# Altium schematic sheet New Javanes Sheet2.SchDoc
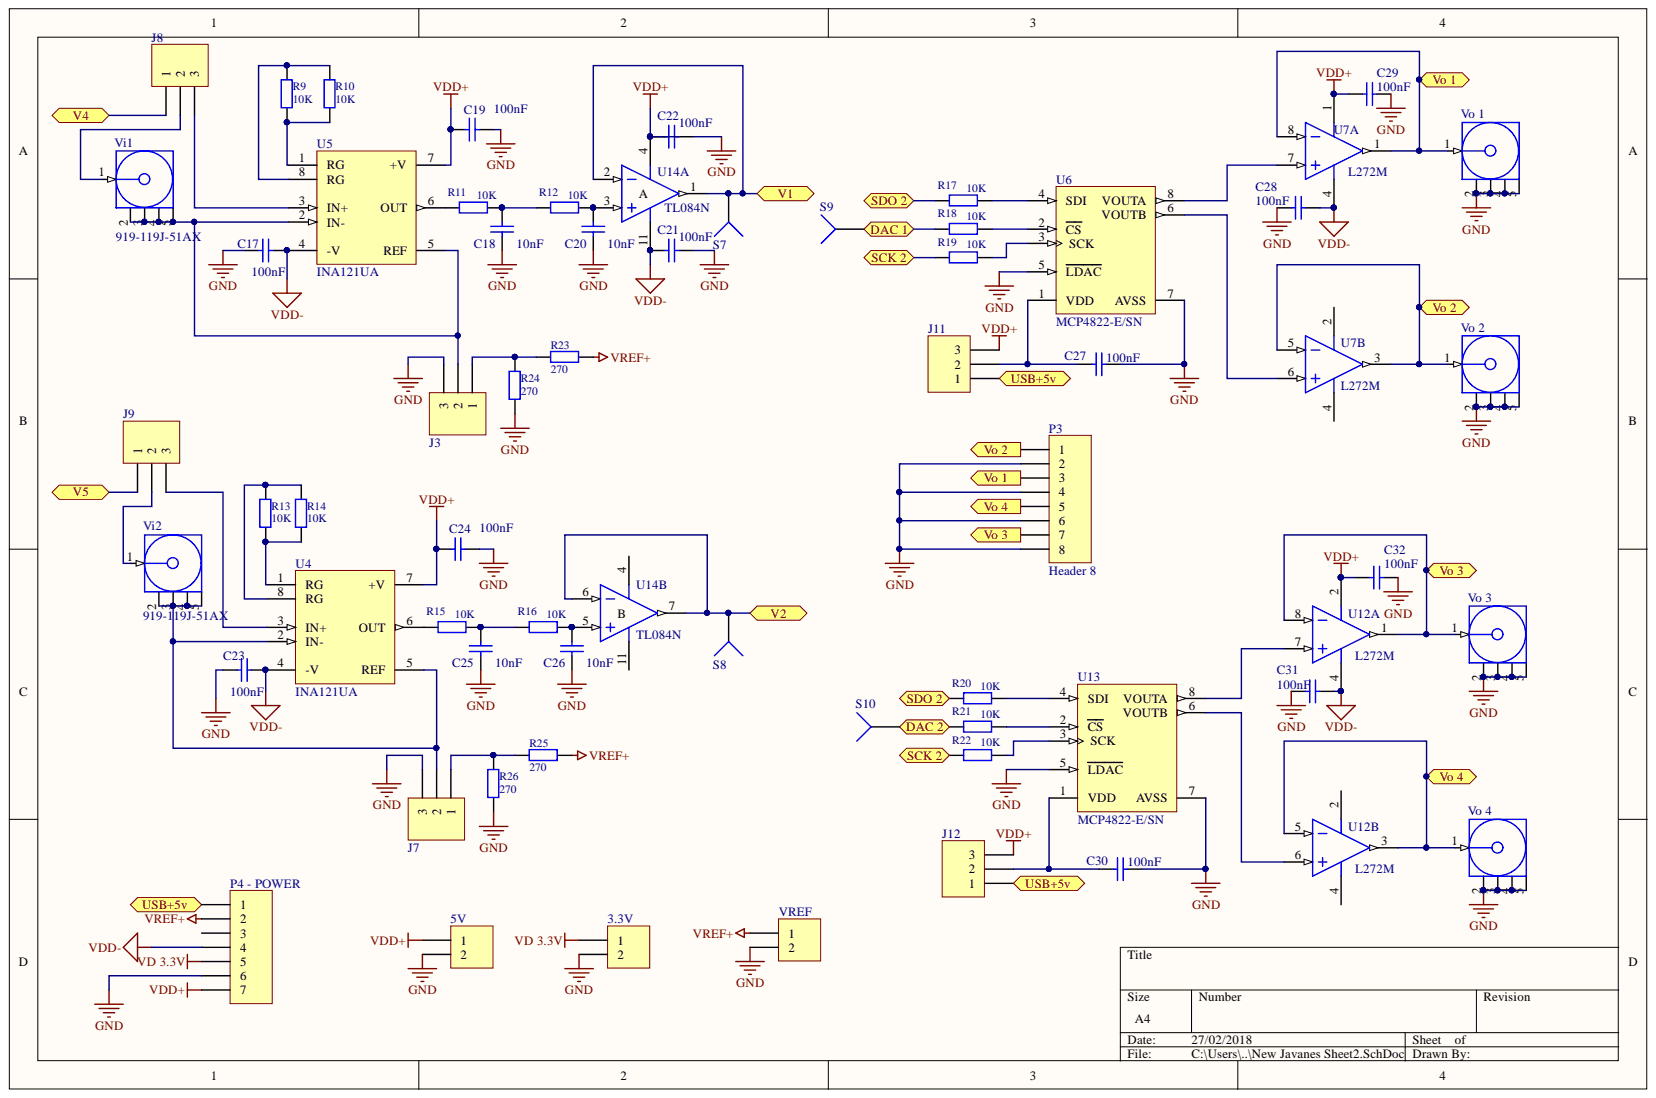
[diagram p0: New Javanes Sheet2.SchDoc]

## Parts
3.3V  Header 2  [HDR1X2]  (value not drawn)
5V  Header 2  [HDR1X2]  (value not drawn)
C17  value 100nF  [VP45-3.2]  (hidden comment: Cap)
C18  value 10nF  [VP45-3.2]  (hidden comment: Cap)
C19  value 100nF  [VP45-3.2]  (hidden comment: Cap)
C20  value 10nF  [VP45-3.2]  (hidden comment: Cap)
C21  value 100nF  [VP45-3.2]  (hidden comment: Cap)
C22  value 100nF  [VP45-3.2]  (hidden comment: Cap)
C23  value 100nF  [VP45-3.2]  (hidden comment: Cap)
C24  value 100nF  [VP45-3.2]  (hidden comment: Cap)
C25  value 10nF  [VP45-3.2]  (hidden comment: Cap)
C26  value 10nF  [VP45-3.2]  (hidden comment: Cap)
C27  value 100nF  [VP45-3.2]  (hidden comment: Cap)
C28  value 100nF  [VP45-3.2]  (hidden comment: Cap)
C29  value 100nF  [VP45-3.2]  (hidden comment: Cap)
C30  value 100nF  [VP45-3.2]  (hidden comment: Cap)
C31  value 100nF  [VP45-3.2]  (hidden comment: Cap)
C32  value 100nF  [VP45-3.2]  (hidden comment: Cap)
J3  Header 3  [HDR1X3]  (value not drawn)
J7  Header 3  [HDR1X3]  (value not drawn)
J8  Header 3  [HDR1X3]  (value not drawn)
J9  Header 3  [HDR1X3]  (value not drawn)
J11  Header 3  [HDR1X3]  (value not drawn)
J12  Header 3  [HDR1X3]  (value not drawn)
P3  Header 8  [HDR1X8]
P4_-_POWER  Header 7  [HDR1X7]  (value not drawn)
R9  value 10K  [AXIAL-0.3]  (hidden comment: Res2)
R10  value 10K  [AXIAL-0.3]  (hidden comment: Res2)
R11  value 10K  [AXIAL-0.3]  (hidden comment: Res2)
R12  value 10K  [AXIAL-0.3]  (hidden comment: Res2)
R13  value 10K  [AXIAL-0.3]  (hidden comment: Res2)
R14  value 10K  [AXIAL-0.3]  (hidden comment: Res2)
R15  value 10K  [AXIAL-0.3]  (hidden comment: Res2)
R16  value 10K  [AXIAL-0.3]  (hidden comment: Res2)
R17  value 10K  [AXIAL-0.3]  (hidden comment: Res2)
R18  value 10K  [AXIAL-0.3]  (hidden comment: Res2)
R19  value 10K  [AXIAL-0.3]  (hidden comment: Res2)
R20  value 10K  [AXIAL-0.3]  (hidden comment: Res2)
R21  value 10K  [AXIAL-0.3]  (hidden comment: Res2)
R22  value 10K  [AXIAL-0.3]  (hidden comment: Res2)
R23  value 270  [AXIAL-0.3]  (hidden comment: Res2)
R24  value 270  [AXIAL-0.3]  (hidden comment: Res2)
R25  value 270  [AXIAL-0.3]  (hidden comment: Res2)
R26  value 270  [AXIAL-0.3]  (hidden comment: Res2)
S7  Socket  [PIN1]  (value not drawn)
S8  Socket  [PIN1]  (value not drawn)
S9  Socket  [PIN1]  (value not drawn)
S10  Socket  [PIN1]  (value not drawn)
U4  INA121UA  [JG008]
U5  INA121UA  [JG008]
U6  MCP4822-E/SN  [PDIP300-8]
U7  L272M  [PDIP300-8]  (parts A,B)
U12  L272M  [PDIP300-8]  (parts A,B)
U13  MCP4822-E/SN  [PDIP300-8]
U14  TL084N  [N014]  (parts A,B)
Vi1  919-119J-51AX  [919-119J]
Vi2  919-119J-51AX  [919-119J]
Vo_1  919-119J-51AX  [919-119J]
Vo_2  919-119J-51AX  [919-119J]
Vo_3  919-119J-51AX  [919-119J]
Vo_4  919-119J-51AX  [919-119J]
VREF  Header 2  [HDR1X2]  (value not drawn)

## Ports
DAC 1, DAC 2, SCK 2, SDO 2, USB+5v, V1, V2, V4, V5, Vo 1, Vo 2, Vo 3, Vo 4

## Nets
GND: 3.3V.2 5V.2 C17.2 C18.2 C19.1 C20.2 C21.1 C22.1 C23.2 C24.1 C25.2 C26.2 C27.2 C28.1 C29.2 C30.2 C31.1 C32.2 J3.3 J7.3 P3.2 P3.4 P3.6 P3.8 P4_-_POWER.6 R24.1 R26.1 U6.5(LDAC) U6.7(AVSS) U13.5(LDAC) U13.7(AVSS) Vo_1.2 Vo_1.3 Vo_1.4 Vo_1.5 Vo_2.2 Vo_2.3 Vo_2.4 Vo_2.5 Vo_3.2 Vo_3.3 Vo_3.4 Vo_3.5 Vo_4.2 Vo_4.3 Vo_4.4 Vo_4.5 VREF.2
VD 3.3V: 3.3V.1 P4_-_POWER.5
VDD+: 5V.1 C19.2 C22.2 C24.2 C29.1 C32.1 J11.3 J12.3 P4_-_POWER.7 U4.7(+V) U5.7(+V) U7.1 U12.2 U14.4
VDD-: C17.1 C21.2 C23.1 C28.2 C31.2 P4_-_POWER.4 U4.4(-V) U5.4(-V) U7.4 U12.4 U14.11
VREF+: P4_-_POWER.2 R23.1 R25.1 VREF.1
DAC 1: R18.2 S9.1 ; port DAC 1
DAC 2: R21.2 S10.1 ; port DAC 2
SCK 2: R19.2 R22.2 ; port SCK 2
SDO 2: R17.2 R20.2 ; port SDO 2
USB+5v: J11.1 J12.1 P4_-_POWER.1 ; port USB+5v
V1: S7.1 U14.1 U14.2 ; port V1
V2: S8.1 U14.6 U14.7 ; port V2
V4: J8.1 ; port V4
V5: J9.1 ; port V5
Vo 1: P3.3 U7.1 U7.8 Vo_1.1 ; port Vo 1
Vo 2: P3.1 U7.3 U7.5 Vo_2.1 ; port Vo 2
Vo 3: P3.7 U12.1 U12.8 Vo_3.1 ; port Vo 3
Vo 4: P3.5 U12.3 U12.5 Vo_4.1 ; port Vo 4
NetC18_1: C18.1 R11.1 R12.2
NetC20_1: C20.1 R12.1 U14.3
NetC25_1: C25.1 R15.1 R16.2
NetC26_1: C26.1 R16.1 U14.5
NetC27_1: C27.1 J11.2 U6.1(VDD)
NetC30_1: C30.1 J12.2 U13.1(VDD)
NetJ3_1: J3.1 R23.2 R24.2
NetJ3_2: J3.2 U5.2(IN-) U5.5(REF) Vi1.2 Vi1.3 Vi1.4 Vi1.5
NetJ7_1: J7.1 R25.2 R26.2
NetJ7_2: J7.2 U4.2(IN-) U4.5(REF) Vi2.2 Vi2.3 Vi2.4 Vi2.5
NetJ8_2: J8.2 Vi1.1
NetJ8_3: J8.3 U5.3(IN+)
NetJ9_2: J9.2 Vi2.1
NetJ9_3: J9.3 U4.3(IN+)
NetR9_1: R9.1 R10.1 U5.1(RG)
NetR9_2: R9.2 R10.2 U5.8(RG)
NetR11_2: R11.2 U5.6(OUT)
NetR13_1: R13.1 R14.1 U4.8(RG)
NetR13_2: R13.2 R14.2 U4.1(RG)
NetR15_2: R15.2 U4.6(OUT)
NetR17_1: R17.1 U6.4(SDI)
NetR18_1: R18.1 U6.2(CS)
NetR19_1: R19.1 U6.3(SCK)
NetR20_1: R20.1 U13.4(SDI)
NetR21_1: R21.1 U13.2(CS)
NetR22_1: R22.1 U13.3(SCK)
NetU6_6: U6.6(VOUTB) U7.6
NetU6_8: U6.8(VOUTA) U7.7
NetU12_6: U12.6 U13.6(VOUTB)
NetU12_7: U12.7 U13.8(VOUTA)

Not connected: P4_-_POWER 3; U7 2

## Library data of the parts
3.3V 5V VREF = Header 2: Header, 2-Pin; Component Kind: Standard; LatestRevisionDate: 17-Jul-2002; LatestRevisionNote: Re-released for DXP Platform.; Library Name: Miscellaneous Connectors.IntLib; Signal Integrity: Connector; Publisher: Altium Limited
C17-C32 = Cap: Capacitor; Component Kind: Standard; LatestRevisionDate: 17-Jul-2002; LatestRevisionNote: Re-released for DXP Platform.; Library Name: Miscellaneous Devices.IntLib; PackageReference: RAD-0.3; Published: 8-Jun-2000; Signal Integrity: Cap; Simulation: CAP; Publisher: Altium Limited
J3 J7-J9 J11 J12 = Header 3: Header, 3-Pin; Component Kind: Standard; LatestRevisionDate: 17-Jul-2002; LatestRevisionNote: Re-released for DXP Platform.; Library Name: Miscellaneous Connectors.IntLib; Signal Integrity: Connector; Publisher: Altium Limited
P3 = Header 8: Header, 8-Pin; Component Kind: Standard; LatestRevisionDate: 17-Jul-2002; LatestRevisionNote: Re-released for DXP Platform.; Library Name: Miscellaneous Connectors.IntLib; Signal Integrity: Connector; Publisher: Altium Limited
P4_-_POWER = Header 7: Header, 7-Pin; Component Kind: Standard; LatestRevisionDate: 17-Jul-2002; LatestRevisionNote: Re-released for DXP Platform.; Library Name: Miscellaneous Connectors.IntLib; Signal Integrity: Connector; Publisher: Altium Limited
R9-R26 = Res2: Resistor; Component Kind: Standard; LatestRevisionDate: 17-Jul-2002; LatestRevisionNote: Re-released for DXP Platform.; Library Name: Miscellaneous Devices.IntLib; PackageReference: AXIAL-0.4; Published: 8-Jun-2000; Signal Integrity: Res; Simulation: RESISTOR; Publisher: Altium Limited
U4 U5 = INA121UA: FET-Input, Low Power Instrumentation Amplifier; Code_IPC: SOIC127P600-8; Code_JEDEC: MS-012-AA; Component Kind: Standard; ComponentLink1Description: Manufacturer Link; ComponentLink1URL: http://www.ti.com/; ComponentLink2Description: Datasheet; ComponentLink2URL: http://www-s.ti.com/sc/ds/INA121.pdf; DatasheetVersion: May-1998; LatestRevisionDate: 13-Apr-2006; LatestRevisionNote: IPC-7351 Footprint Added.; Library Name: BB Instrumentation Amplifier.IntLib; PackageDescription: 8-Pin Small Outline Integrated Circuit 1.27 mm Pitch; PackageReference: 182H; PCB3D: SOIC8; Published: 17-Jul-2002; Simulation: INA121E; Publisher: Altium Limited
U6 U13 = MCP4822-E/SN: 12-Bit DACs with Internal VREF and SPI Interface, 8-Pin SOIC 150mil, Extended Temperature; Code_IPC: SOIC127P600-8; Code_JEDEC: MS-012; Component Kind: Standard; ComponentLink1Description: Manufacturer Link; ComponentLink1URL: http://www.microchip.com; ComponentLink2Description: Datasheet; ComponentLink2URL: http://ww1.microchip.com/downloads/en/DeviceDoc/21953a.pdf; ComponentLink3Description: Packaging Specification; ComponentLink3URL: http://ww1.microchip.com/downloads/en/PackagingSpec/00049AW.pdf; DatasheetVersion: revA, Jun-2005; PackageReference: SOIC-SN8; PackageVersion: revAW, Oct-2008; Published: 29-Jan-2009; Library Name: Microchip Mixed Signal.IntLib; Publisher: Altium Limited
U7 U12 = L272M: Dual Power Operational Amplifier; Code_JEDEC: MO-001-AN; Component Kind: Standard; ComponentLink1Description: Manufacturer Link; ComponentLink1URL: http://www.st.com/; ComponentLink2Description: Datasheet; ComponentLink2URL: http://www.st.com/stonline/products/literature/ds/1320/l272.pdf; DatasheetVersion: Jan-1995; LatestRevisionDate: 06-Jun-2005; LatestRevisionNote: Stylized 3D Model Added.; Library Name: ST Operational Amplifier.IntLib; PackageDescription: DIP; 8 Leads; Row Spacing 7.62 mm; Pitch 2.54 mm; PackageReference: DIP8; PCB3D: DIP8; Published: 24-Aug-2001; Publisher: Altium Limited
U14 = TL084N: JFET-Input Operational Amplifier; Component Kind: Standard; ComponentLink1Description: Manufacturer Link; ComponentLink1URL: http://www.ti.com/; ComponentLink2Description: Datasheet; ComponentLink2URL: http://www-s.ti.com/sc/ds/tl084.pdf; DatasheetVersion: Feb-1999; LatestRevisionDate: 04-Mar-2005; LatestRevisionNote: Stylized 3D Model Added.; Library Name: TI Operational Amplifier.IntLib; PackageDescription: DIP; 14 Leads; Row Spacing 7.62 mm; Pitch 2.54 mm; PackageReference: N014; PackageVersion: Oct-1995; PCB3D: N014; Published: 16-Jan-2001; Simulation: TL084; Publisher: Altium Limited
Vi1 Vi2 Vo_1-Vo_4 = 919-119J-51AX: MCX RF Coaxial PCB Connector, Thru-Hole, Right-Angle Mount Socket, Blunt Post Terminal, 50 Ohm Impedance; DrillSize: Corresponds to nominal value.; PCB_Layout: Complies with manufacturer's recommendation.; Component Kind: Standard; ComponentLink1Description: Manufacturer Link; ComponentLink1URL: http://www.amphenolrf.com/; ComponentLink2Description: Datasheet; ComponentLink2URL: http://www.amphenolrf.com/pdf/21.pdf; DatasheetDocument: 30-May-2003; Library Name: Amphenol RF Coaxial MCX.IntLib; PackageDocument: 04-Jul-1999; PackageReference: 919-119J; Published: 15-Jul-2004; Publisher: Altium Limited
S7-S10 = Socket: Component Kind: Standard; Description: Socket; LatestRevisionDate: 17-Jul-2002; LatestRevisionNote: Re-released for DXP Platform.; Library Name: Miscellaneous Connectors.IntLib; Signal Integrity: Connector; Publisher: Altium Limited
